# Altium schematic sheet wls2-blue-40R204.SchDoc(wls2-blue-40R204)
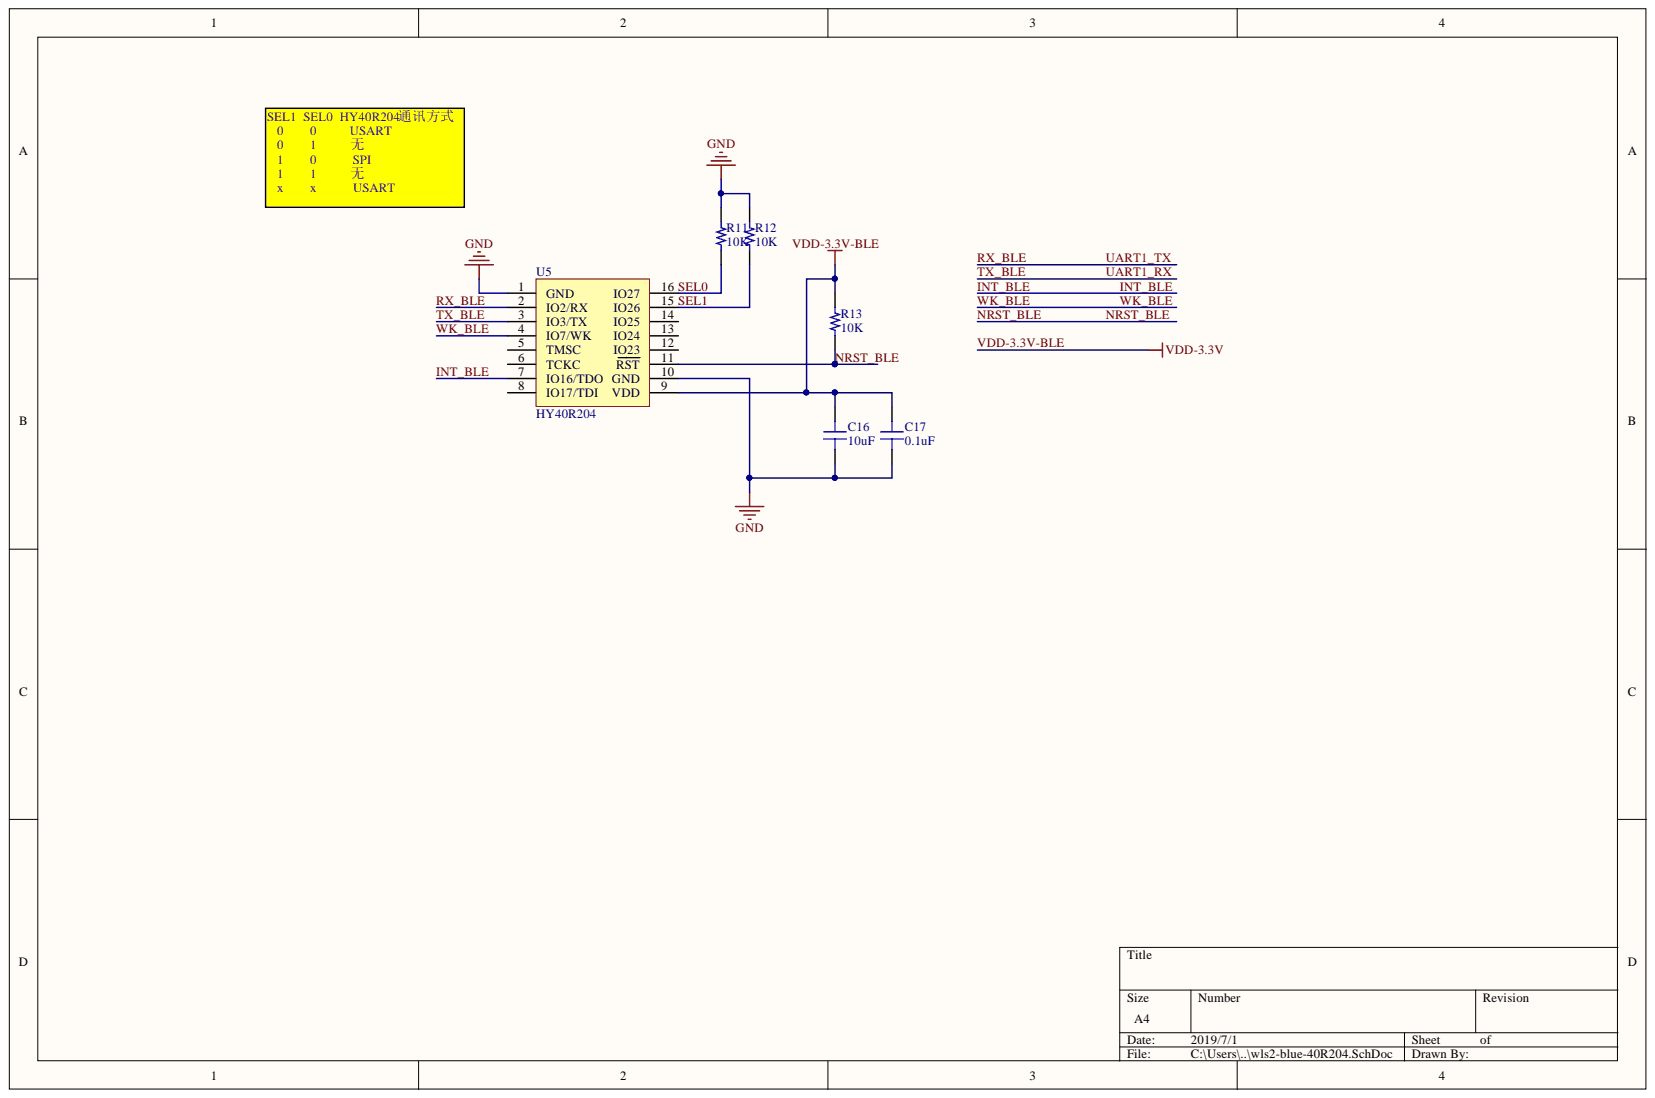
[diagram p0: wls2-blue-40R204.SchDoc]

## Parts
C16  10uF  [B0805C]
C17  0.1uF  [RES 0402/1005]
R11  10K  [RES 0402/1005]
R12  10K  [RES 0402/1005]
R13  10K  [RES 0402/1005]
U5  HY40R204  [HY40R204PC]

## Nets
GND: C16.1 C17.1 R11.2 R12.2 U5.1(GND) U5.10(GND)
INT_BLE: U5.7(IO16/TDO)
NRST_BLE: R13.1 U5.11(RST)
RX_BLE: U5.2(IO2/RX)
SEL0: R11.1 U5.16(IO27)
SEL1: R12.1 U5.15(IO26)
TX_BLE: U5.3(IO3/TX)
VDD-3.3V-BLE: C16.2 C17.2 R13.2 U5.9(VDD)
WK_BLE: U5.4(IO7/WK)

Not connected: U5 5(TMSC) 6(TCKC) 8(IO17/TDI) 12(IO23) 13(IO24) 14(IO25)

## Library data of the parts
C16 C17 = Capacitor: SMT Capacitor; Component Kind: Standard; Library Name: Passives.SchLib
  C16: Value: 10uF
  C17: Value: 0.1uF
R11-R13 = Resistor: SMT Resistor; Component Kind: Standard; Library Name: Passives.SchLib; Value: 10K
U5 = HY40R204P: HY40R204PC; Component Kind: Standard; Library Name: device_sch_lib.SchLib
